# Altium schematic sheet lv_FANS.SchDoc(lv_FANS)
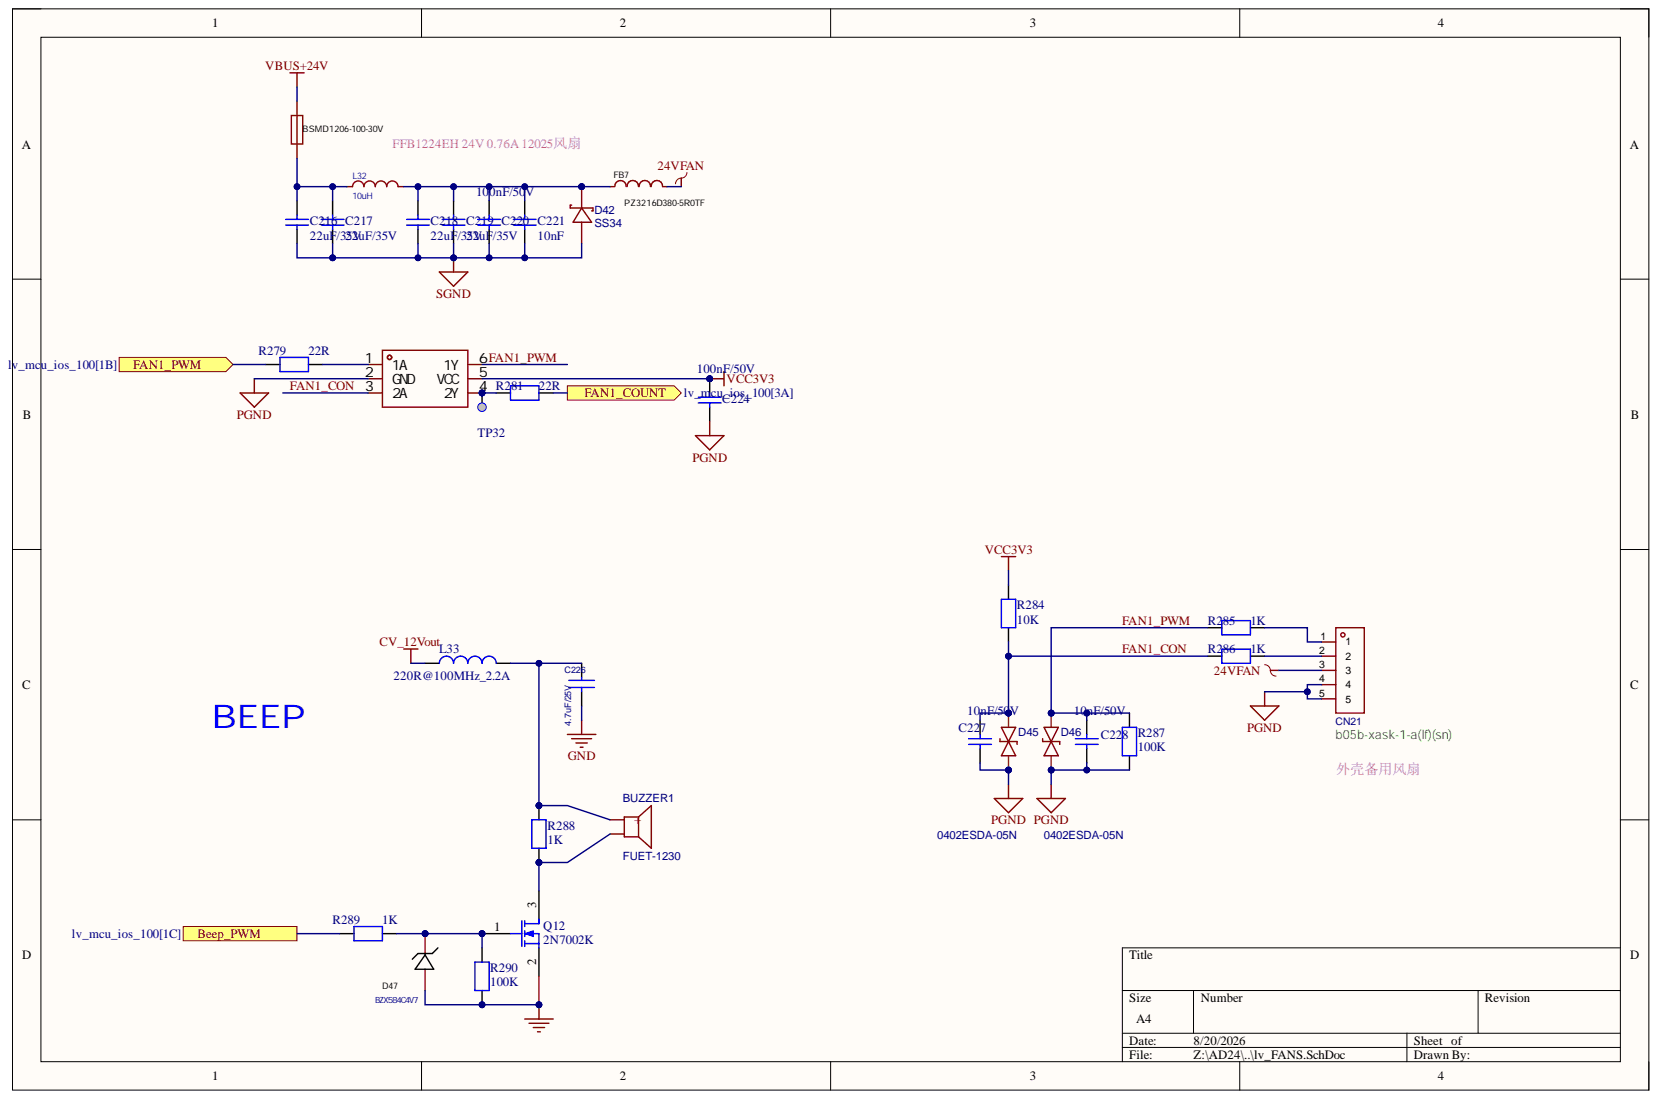
[diagram p0: lv_FANS.SchDoc]

## Parts
BUZZER1  FUET-1230  [BUZ-SMD_L12.0-W12.0-P11.6]
C216  22uF/35V  [C1206]
C217  22uF/35V  [C1206]
C218  22uF/35V  [C1206]
C219  22uF/35V  [C1206]
C220  100nF/50V  [C0402]
C221  value 10nF  [C0402]  (hidden comment: 10nF/50V)
C224  100nF/50V  [C0402]
C226  4.7uF/25V  [C0603]
C227  10nF/50V  [C0402]
C228  10nF/50V  [C0402]
CN21  b05b-xask-1-a(lf)(sn)  [CONN-TH_B05B-XASK-1-A-LF-SN]
D42  SS34  [DO-214AC_L4.3-W2.7-LS5.3-RD]
D45  0402ESDA-05N  [D0402-BI]
D46  0402ESDA-05N  [D0402-BI]
D47  BZX584C4V7  [SOD-523F_L1.2-W0.8-LS1.7-RD]
F7  BSMD1206-100-30V  [1206]
FB7  PZ3216D380-5R0TF  [L1206]
L32  10uH  [IND-SMD_L3.0-W3.0_FTC303020D]
L33  220R@100MHz_2.2A  [L0603]
Q12  2N7002K  [SOT-23(SOT-23-3)]
R279  22R  [R0402]
R281  22R  [R0402]
R284  10K  [R0603]
R285  1K  [R0603]
R286  1K  [R0603]
R287  100K  [R0603]
R288  1K  [R0603]
R289  1K  [R0402]
R290  100K  [R0402]
TP32  Test Point  [TP_SMD_1MM]  (value not drawn)  (no BOM)
U56  74LVC2G17GW,125  [SOT-363_L2.0-W1.3-P0.65-LS2.1-BR]  (value not drawn)

## Ports
Beep_PWM, FAN1_COUNT, FAN1_PWM

## Nets
24VFAN: CN21.3 FB7.1
CV_12Vout: L33.2
GND: C226.2 D47.2 Q12.2 R290.1
PGND: C224.1 C227.1 C228.1 CN21.4 CN21.5 D45.1 D46.1 R287.1 U56.2(GND)
SGND: C216.1 C217.1 C218.1 C219.1 C220.1 C221.1 D42.2
VBUS+24V: F7.2
VCC3V3: C224.2 R284.2 U56.5(VCC)
Beep_PWM: R289.1 ; port Beep_PWM
FAN1_CON: C227.2 D45.2 R284.1 R286.2 U56.3(2A)
FAN1_COUNT: R281.2 ; port FAN1_COUNT
FAN1_PWM: C228.2 D46.2 R285.2 R287.2 U56.6(1Y)
NetBUZZER1_1: BUZZER1.1 C226.1 L33.1 R288.2
NetBUZZER1_2: BUZZER1.2 Q12.3 R288.1
NetC216_2: C216.2 C217.2 F7.1 L32.2
NetC218_2: C218.2 C219.2 C220.2 C221.2 D42.1 FB7.2 L32.1
NetCN21_1: CN21.1 R285.1
NetCN21_2: CN21.2 R286.1
NetD47_1: D47.1 Q12.1 R289.2 R290.2
NetR279_1: R279.1 ; port FAN1_PWM
NetR279_2: R279.2 U56.1(1A)
NetR281_1: R281.1 TP32.1 U56.4(2Y)

## Library data of the parts
BUZZER1 = FST-1230: buzzer; BOM_Manufacturer: FUET; Contributor: LCSC; Frequency: 4000Hz; BOM_JLCPCB Part Class: Extended Part; BOM_Manufacturer Part: FST-1230; BOM_Supplier: LCSC; BOM_Supplier Part: C391037; Component Kind: Standard; Library Name: HiPin_Library_AD.IntLib; spicePre: B; spiceSymbolName: FST-1230
C216-C219 = Capacitor_2: 35V, X5R, 10%; Manufacturer: TDK; Manufacturer_Part_Number: C3216X5R1V226MTJ00E; Component Kind: Standard; ComponentLink1Description: Datasheet; ComponentLink1URL: http://tunmcdsvn.tun.st.com/Component_Datasheet_Library/Trunk/Capacitors/Yageo_Multilayer_capacitor_X5R_series_100pF_to_100uF; Library Name: HiPin_Library_AD.IntLib
C220 C224 = Capacitor: 50V, 10%, X7R; Internal_Part_Number: MCD-00003; Manufacturer: muRata; Manufacturer_Part_Number: GRM155R71H104KE14D; Value: 100nF; Component Kind: Standard; ComponentLink1Description: Datasheet; ComponentLink1URL: http://tunmcdsvn.tun.st.com/Component_Datasheet_Library/Trunk/Capacitors/Yageo_Capacitor_NP0_16V-to-50V; Library Name: HiPin_Library_AD.IntLib; Package: C0402
C221 = Capacitor: 50V, 10%, X7R; Internal_Part_Number: MCD-00003; Manufacturer: muRata; Manufacturer_Part_Number: GRM155R71H104KE14D; Component Kind: Standard; ComponentLink1Description: Datasheet; ComponentLink1URL: http://tunmcdsvn.tun.st.com/Component_Datasheet_Library/Trunk/Capacitors/Yageo_Capacitor_NP0_16V-to-50V; Library Name: HiPin_Library_AD.IntLib; Package: C0402
C226 = CAP NP: X5R, 10%; Manufacturer: muRata; Manufacturer_Part_Number: GRM188R61E475KE11D; Component Kind: Standard
C227 C228 = Capacitor: 50V, 10%, X7R; Internal_Part_Number: MCD-00003; Manufacturer: muRata; Manufacturer_Part_Number: GRM155R71H104KE14D; Value: 10nF; Component Kind: Standard; ComponentLink1Description: Datasheet; ComponentLink1URL: http://tunmcdsvn.tun.st.com/Component_Datasheet_Library/Trunk/Capacitors/Yageo_Capacitor_NP0_16V-to-50V; Library Name: HiPin_Library_AD.IntLib; Package: C0402
CN21 = b05b-xask-1-a(lf)(sn): 插针结构:1x5P;间距:2.5mm;安装方式:直插;参考系列:XA;总PIN数:5P;排数:1;每排PIN数:5;行距:-;额定电流:3A;触头材质:铜合金;触头镀层:锡;工作温度:-25℃~+85℃;; Manufacturer: JST; AddInBom: yes; Current Rating: 3A; Flame Retardant Rating: UL94V-0; ModelId: e0ab7f3b215b4606a0772da92fe8ba27; ModelTransform: 590.58937,248.031,0,0,0,0,0,0,-118.11; Number of PINs Per Row: 5; Pins Structure: 1x5P; Pitch: 2.5mm; Plastic Material: 玻纤增强PA66; Reference Series: XA; Supplementary Features: 打K脚；带柱; Voltage Rating: 250V; X-Length of Bottom Edge on Board (Spacing Line): 15mm; Y-Width of Bottom Edge on Board: 6.4mm; Z-Height of the Board: 8.8mm; Color: 白色; Component Kind: Standard; Contact Material: 铜合金; Contact Plating: 锡; ConvertToPcb: yes; Datasheet: https://item.szlcsc.com/datasheet/B05B-XASK-1-A(LF)(SN)/502110.html; FootprintName: CONN-TH_B05B-XASK-1-A-LF-SN; JLCPCB Part Class: Extended Part; LCSC Part Name: 1x5P 间距:2.5mm 直插 系列:XA; Library Name: HiPin_Library_AD.IntLib; ManufacturerPart: B05B-XASK-1-A(LF)(SN); ModelName: CONN-TH_B05B-XASK-1-A-LF-SN; Mounting Type: 直插; Number of Pins: 5P; Number of Rows: 1; Operating Temperature: -25℃~+85℃; Row Spacing: -; Supplier: LCSC; Supplier Footprint: 插件,P=2.5mm; SupplierPart: C491708; Symbol: B05B-XASK-1-A(LF)(SN)
D42 = SS34_C8678: 肖特基二极管二极管，40V，3A,550mV@3A; BOM_Manufacturer: MDD; BOM_JLCPCB Part Class: Basic Part; BOM_Manufacturer Part: SS34; BOM_Supplier: LCSC; BOM_Supplier Part: C8678; Component Kind: Standard; Library Name: HiPin_Library_AD.IntLib; spicePre: D; spiceSymbolName: SS34_C8678
D45 D46 = 0402ESDA-05N: 双向ESD，反向截止电压5V; BOM_Manufacturer: Bourne Semicon (Shenzhen); Contributor: LCSC; BOM_JLCPCB Part Class: Extended Part; BOM_Manufacturer Part: 0402ESDA-05N; BOM_Supplier: LCSC; BOM_Supplier Part: C316049; Component Kind: Standard; Library Name: HiPin_Library_AD.IntLib; spicePre: D; spiceSymbolName: 0402ESDA-05N
D47 = BZT52C4V7S: Manufacturer: CJ(江苏长电/长晶); Add into BOM: yes; Diode Configuration: 独立式; Power Dissipation: 500mW; Reverse Leakage Current (Ir): 3uA@4V; Zener Impedance (Zzt): 10Ω; Zener Voltage (Nom): 6.2V; Zener Voltage (Range): 5.8V~6.6V; Component Kind: Standard; Convert to PCB: yes; Datasheet: https://atta.szlcsc.com/upload/public/pdf/source/20211105/0A7AF84D57D732370551C8DD7A10F563.pdf; JLCPCB Part Class: Extended Part; Library Name: HiPin_Library_AD.IntLib; Manufacturer Part: BZX584C4V7; Name: BZX584C4V7; Supplier: LCSC; Supplier Footprint: SOD-123; Tolerance: -
F7 = 2920L260/24DR: 耐压:30V,保持电流(Ih): 1A,跳闸电流: 1.8A; Manufacturer: HFUSE(佰宏); Manufacturer Part: BSMD1206-100-24V; Component Kind: Standard; Library Name: HiPin_Library_AD.IntLib
FB7 = BLM31PG601SN1L: 38Ω@100MHz 5A 15mΩ ±25%; Manufacturer: Sunlord(顺络); Add into BOM: yes; Circuits: 1; Current Rating: 1.5A; DC Resistance: 80mΩ; Impedance @ Frequency: 600Ω@100MHz; Component Kind: Standard; Convert to PCB: yes; Datasheet: https://atta.szlcsc.com/upload/public/pdf/source/20180326/C88997_FE7208E239BA92CF7577D85CF2703D30.pdf; Device: BLM31PG601SN1L; JLCPCB Part Class: Extended Part; LCSC Part Name: 38Ω@100MHz 5A; Library Name: HiPin_Library_AD.IntLib; Manufacturer Part: PZ3216D380-5R0TF; Supplier: LCSC; Supplier Footprint: 1206; Supplier Part: C16902; Symbol: BLM31PG601SN1L; Tolerance: ±25%
L32 = FTC303020D100MBCA: 一体成型电感，2.5A，20%; Manufacturer: cjiang(长江微电); Add into BOM: yes; DC Resistance (DCR): 135mΩ; Rated Current: 2.5A; Saturation Current (Isat): 3.8A; Tolerance: ±20%; Type: 一体成型电感; Component Kind: Standard; Convert to PCB: yes; Datasheet: https://atta.szlcsc.com/upload/public/pdf/source/20230614/D39208D019DF4F1446B96572E3A14872.pdf; Device: FTC303020D100MBCA; JLCPCB Part Class: Extended Part; LCSC Part Name: 10uH ±20% 3.8A; Library Name: HiPin_Library_AD.IntLib; Manufacturer Part: FTC303020D100MBCA; PCB3D: IND-SMD_L3.0-W3.0_FTC303020D; Supplier: LCSC; Supplier Footprint: SMD,3x3mm; Supplier Part: C7423323; Symbol: FTC303020D100MBCA; Value: 10uH
L33 = MCD-00047: SMD Chip ferrite bead, 220R@100MHz +/-25%,2.2A, 50mohm; Manufacturer: Sunlord; Manufacturer_Part_Number: UPZ1608U221-2R2TF; Database Table Name: MCD_PARTS$; Component Kind: Standard; ComponentLink1Description: Datasheet; ComponentLink1URL: http://tunmcdsvn.tun.st.com/Component_Datasheet_Library/Trunk/Inductors/TaiTech_Bead_FCM1608_series; Internal_Part_Number: MCD-00047; Library Name: MCD_PARTS_database.DbLib
Q12 = MOSFET-N: N沟道,60V,0.115A,7Ω@10V; Manufactu: CJ江苏长电; ManufacturerNumber: 2N7002; Component Kind: Standard; Library Name: HiPin_Library_AD.IntLib; Package: SOT-23; SuppliersPartNumber: C8545
R279 R281 R285-R287 R289 R290 = RES: 1%; Value: 10K; Component Kind: Standard; Library Name: HiPin_Library_AD.IntLib
R284 = RES: 1%; Component Kind: Standard; Library Name: HiPin_Library_AD.IntLib; Value: 10K
R288 = RES: Resistor; Component Kind: Standard; Library Name: HiPin_Library_AD.IntLib
TP32 = MCD-00074: Test Point 1mm; Part_Type: Mechanical; Database Table Name: MCD_PARTS$; ComponentLink1Description: Datasheet; ComponentLink1URL: http://na; ECCN_EU: n/a; ECCN_US: n/a; Internal_Part_Number: MCD-00074; Library Name: MCD_PARTS_database.DbLib; Manufacturer: n/a; Manufacturer_Part_Number: n/a; Provided_By: n/a; Status: Released
U56 = 74LVC2G17GW,125: 2路斯密特触发器; Manufacturer: Nexperia(安世); Add into BOM: yes; Current - Output High(IOH): 32mA; Input type: 施密特触发器; Logic Family: 74LVC; Number of Bits per Element: 1; Number of Elements: 2; output type: 推挽; Voltage - Supply: 1.65V~5.5V; Component Kind: Standard; Convert to PCB: yes; Current - Output Low(IOL): 32mA; Datasheet: https://atta.szlcsc.com/upload/public/pdf/source/20240724/F8BC06F6786231A20F016105A2EDA3EF.pdf; Device: 74LVC2G17GW,125; JLCPCB Part Class: Extended Part; LCSC Part Name: 74LVC2G17GW,125; Library Name: HiPin_Library_AD.IntLib; Manufacturer Part: 74LVC2G17GW,125; Operating Temperature: -40℃~+125℃; PCB3D: SOT-363-6P_L2.0-W1.3-H1.1-LS2.0-P0.65; Supplier: LCSC; Supplier Footprint: SOT-363; Supplier Part: C80500; Symbol: 74LVC2G17GW,125
